# Altium schematic sheet ALTERA_MAX10_ARDUINO_BOARD_PWR_VGA_SD_POT.SchDoc(ALTERA_MAX10_ARDUINO_BOARD_PWR_VGA_SD_POT)
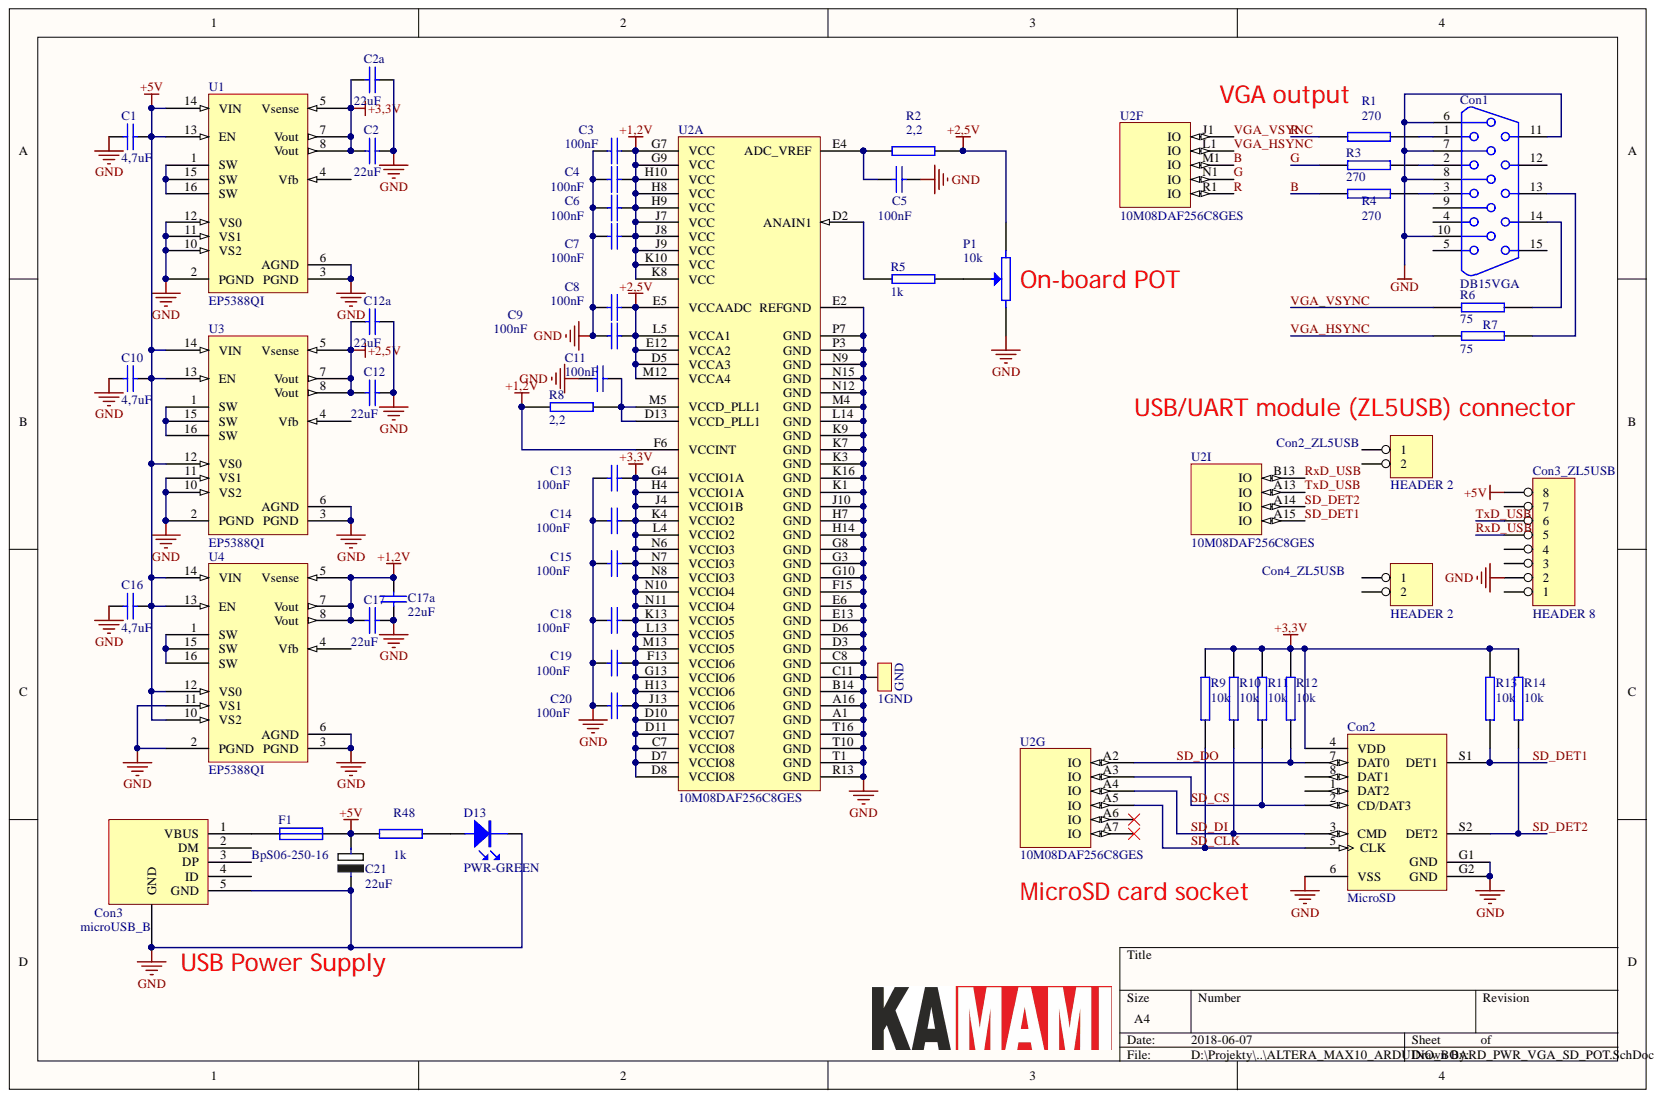
[diagram p0: ALTERA_MAX10_ARDUINO_BOARD_PWR_VGA_SD_POT.SchDoc]

## Parts
C1  4,7uF  [0603C]
C2  22uF  [0603C]
C2a  22uF  [0603C]
C3  100nF  [0603C]
C4  100nF  [0603C]
C5  100nF  [0603C]
C6  100nF  [0603C]
C7  100nF  [0603C]
C8  100nF  [0603C]
C9  100nF  [0603C]
C10  4,7uF  [0603C]
C11  100nF  [0603C]
C12  22uF  [0603C]
C12a  22uF  [0603C]
C13  100nF  [0603C]
C14  100nF  [0603C]
C15  100nF  [0603C]
C16  4,7uF  [0603C]
C17  22uF  [0603C]
C17a  22uF  [0603C]
C18  100nF  [0603C]
C19  100nF  [0603C]
C20  100nF  [0603C]
C21  22uF  [CE_SMD_SIZE_D]
Con1  DB15VGA  [DB15P-VGA]
Con2  MicroSD  [MMC-MICRO-SPREZYNA]
Con2_ZL5USB  HEADER 2  [SIP-2]
Con3  microUSB_B  [MICROUSB-B]
Con3_ZL5USB  HEADER 8  [sip-8]
Con4_ZL5USB  HEADER 2  [SIP-2]
D13  PWR-GREEN  [0805_LED]
F1  BpS06-250-16  [1206F]
GND  1GND  [1GND]
P1  10k  [MINIPOT]
R1  270  [0603R]
R2  2,2  [0603R]
R3  270  [0603R]
R4  270  [0603R]
R5  1k  [0603R]
R6  75  [0603R]
R7  75  [0603R]
R8  2,2  [0603R]
R9  10k  [0603R]
R10  10k  [0603R]
R11  10k  [0603R]
R12  10k  [0603R]
R13  10k  [0603R]
R14  10k  [0603R]
R48  1k  [0603R]
U1  EP5388QI  [EP5388QI]
U2  10M08DAF256C8GES  [BGA256C100P16X16_1700X1700X145]  (parts A,F,G,I)
U3  EP5388QI  [EP5388QI]
U4  EP5388QI  [EP5388QI]

## Nets
+1,2V: C3.2 C4.2 C6.2 C7.2 C17.1 C17a.2 R8.1 U2.F6(VCCINT) U2.G7(VCC) U2.G9(VCC) U2.H8(VCC) U2.H9(VCC) U2.H10(VCC) U2.J7(VCC) U2.J8(VCC) U2.J9(VCC) U2.K8(VCC) U2.K10(VCC) U4.5(Vsense) U4.7(Vout) U4.8(Vout)
+2,5V: C8.2 C9.2 C12.1 C12a.1 P1.3 R2.2 U2.D5(VCCA3) U2.E5(VCCAADC) U2.E12(VCCA2) U2.L5(VCCA1) U2.M12(VCCA4) U3.5(Vsense) U3.7(Vout) U3.8(Vout)
+3,3V: C2.1 C2a.1 C13.2 C14.2 C15.2 C18.2 C19.2 C20.2 Con2.4(VDD) R9.2 R10.2 R11.2 R12.2 R13.2 R14.2 U1.5(Vsense) U1.7(Vout) U1.8(Vout) U2.C7(VCCIO8) U2.D7(VCCIO8) U2.D8(VCCIO8) U2.D10(VCCIO7) U2.D11(VCCIO7) U2.F13(VCCIO6) U2.G4(VCCIO1A) U2.G13(VCCIO6) U2.H4(VCCIO1A) U2.H13(VCCIO6) U2.J4(VCCIO1B) U2.J13(VCCIO6) U2.K4(VCCIO2) U2.K13(VCCIO5) U2.L4(VCCIO2) U2.L13(VCCIO5) U2.M13(VCCIO5) U2.N6(VCCIO3) U2.N7(VCCIO3) U2.N8(VCCIO3) U2.N10(VCCIO4) U2.N11(VCCIO4)
+5V: C1.1 C10.1 C16.1 C21.1 Con3_ZL5USB.8 F1.2 R48.2 U1.13(EN) U1.14(VIN) U3.12(VS0) U3.13(EN) U3.14(VIN) U4.10(VS2) U4.12(VS0) U4.13(EN) U4.14(VIN)
GND: C1.2 C2.2 C2a.2 C3.1 C4.1 C5.2 C6.1 C7.1 C8.1 C9.1 C10.2 C11.1 C12.2 C12a.2 C13.1 C14.1 C15.1 C16.2 C17.2 C17a.1 C18.1 C19.1 C20.1 C21.2 Con1.6 Con1.7 Con1.8 Con1.10 Con1.11 Con2.6(VSS) Con2.G1(GND) Con2.G2(GND) Con3.0(GND) Con3.5(GND) Con3_ZL5USB.2 D13.2 GND.1 P1.1 U1.2(PGND) U1.3(PGND) U1.6(AGND) U1.10(VS2) U1.11(VS1) U1.12(VS0) U2.A1(GND) U2.A16(GND) U2.B14(GND) U2.C8(GND) U2.C11(GND) U2.D3(GND) U2.D6(GND) U2.E2(REFGND) U2.E6(GND) U2.E13(GND) U2.F15(GND) U2.G3(GND) U2.G8(GND) U2.G10(GND) U2.H7(GND) U2.H14(GND) U2.J10(GND) U2.K1(GND) U2.K3(GND) U2.K7(GND) U2.K9(GND) U2.K16(GND) U2.L14(GND) U2.M4(GND) U2.N9(GND) U2.N12(GND) U2.N15(GND) U2.P3(GND) U2.P7(GND) U2.R13(GND) U2.T1(GND) U2.T10(GND) U2.T16(GND) U3.2(PGND) U3.3(PGND) U3.6(AGND) U3.10(VS2) U3.11(VS1) U4.2(PGND) U4.3(PGND) U4.6(AGND) U4.11(VS1)
B: R4.1 U2.M1(IO)
G: R3.1 U2.N1(IO)
R: R1.1 U2.R1(IO)
RxD_USB: Con3_ZL5USB.5 U2.B13(IO)
SD_CLK: Con2.5(CLK) R9.1 U2.A5(IO)
SD_CS: Con2.2(CD/DAT3) R11.1 U2.A3(IO)
SD_DET1: Con2.S1(DET1) R13.1 U2.A15(IO)
SD_DET2: Con2.S2(DET2) R14.1 U2.A14(IO)
SD_DI: Con2.3(CMD) R10.1 U2.A4(IO)
SD_DO: Con2.7(DAT0) R12.1 U2.A2(IO)
TxD_USB: Con3_ZL5USB.6 U2.A13(IO)
VGA_HSYNC: R7.1 U2.L1(IO)
VGA_VSYNC: R6.1 U2.J1(IO)
NetC5_1: C5.1 R2.1 U2.E4(ADC_VREF)
NetC11_2: C11.2 R8.2 U2.D13(VCCD_PLL1) U2.M5(VCCD_PLL1)
NetCon1_1: Con1.1 R1.2
NetCon1_2: Con1.2 R3.2
NetCon1_3: Con1.3 R4.2
NetCon1_13: Con1.13 R7.2
NetCon1_14: Con1.14 R6.2
NetCon3_1: Con3.1(VBUS) F1.1
NetD13_1: D13.1 R48.1
NetP1_2: P1.2 R5.1
NetR5_2: R5.2 U2.D2(ANAIN1)
NetU1_1: U1.1(SW) U1.15(SW) U1.16(SW)
NetU3_1: U3.1(SW) U3.15(SW) U3.16(SW)
NetU4_1: U4.1(SW) U4.15(SW) U4.16(SW)

Not connected: Con1 4 5 9 12 15; Con2 1(DAT2) 8(DAT1); Con2_ZL5USB 1 2; Con3 2(DM) 3(DP) 4(ID); Con3_ZL5USB 1 3 4 7; Con4_ZL5USB 1 2; U1 4(Vfb); U2 A6(IO) A7(IO); U3 4(Vfb); U4 4(Vfb)

## Library data of the parts
C1 C2 C2a C3-C12 C12a C13-C17 C17a C18-C20 = CAP: Capacitor; Library Name: Miscellaneous Devices.SchLib; Component Kind: Standard
C21 = CP: Capacitor; Library Name: Miscellaneous Devices.SchLib; Component Kind: Standard
Con3 = MINIUSB_B: Library Name: Miscellaneous Devices.SchLib; Component Kind: Standard
Con3_ZL5USB = HEADER 8: 8 Pin Header; Library Name: Miscellaneous Devices.SchLib; Component Kind: Standard
D13 = LED: Library Name: Miscellaneous Devices.SchLib; Component Kind: Standard
F1 = FUSE1: Fuse; Library Name: Miscellaneous Devices.SchLib; Component Kind: Standard
P1 = POT: Potentiometer; Library Name: Miscellaneous Devices.SchLib; Component Kind: Standard
R1 R3 R4 R6 R7 = RES2: Component Kind: Standard
R2 R5 R8-R14 R48 = res2: Library Name: Miscellaneous Devices.SchLib; Component Kind: Standard
Con1 = DB15VGA: Component Kind: Standard
Con2 = MicroSD: Library Name: Miscellaneous Devices.SchLib; Component Kind: Standard
Con2_ZL5USB Con4_ZL5USB = HEADER 2: Library Name: Miscellaneous Devices.SchLib; Component Kind: Standard
GND = 1GND: Library Name: Miscellaneous Devices.SchLib; Component Kind: Standard
U1 U3 U4 = EP5388QI: Library Name: Altera_MAX10.SchLib; Component Kind: Standard
U2 = 10M08DAF256C8GES: Library Name: Altera_MAX10.SchLib; Component Kind: Standard
